# Altium schematic sheet Sheet7.SchDoc
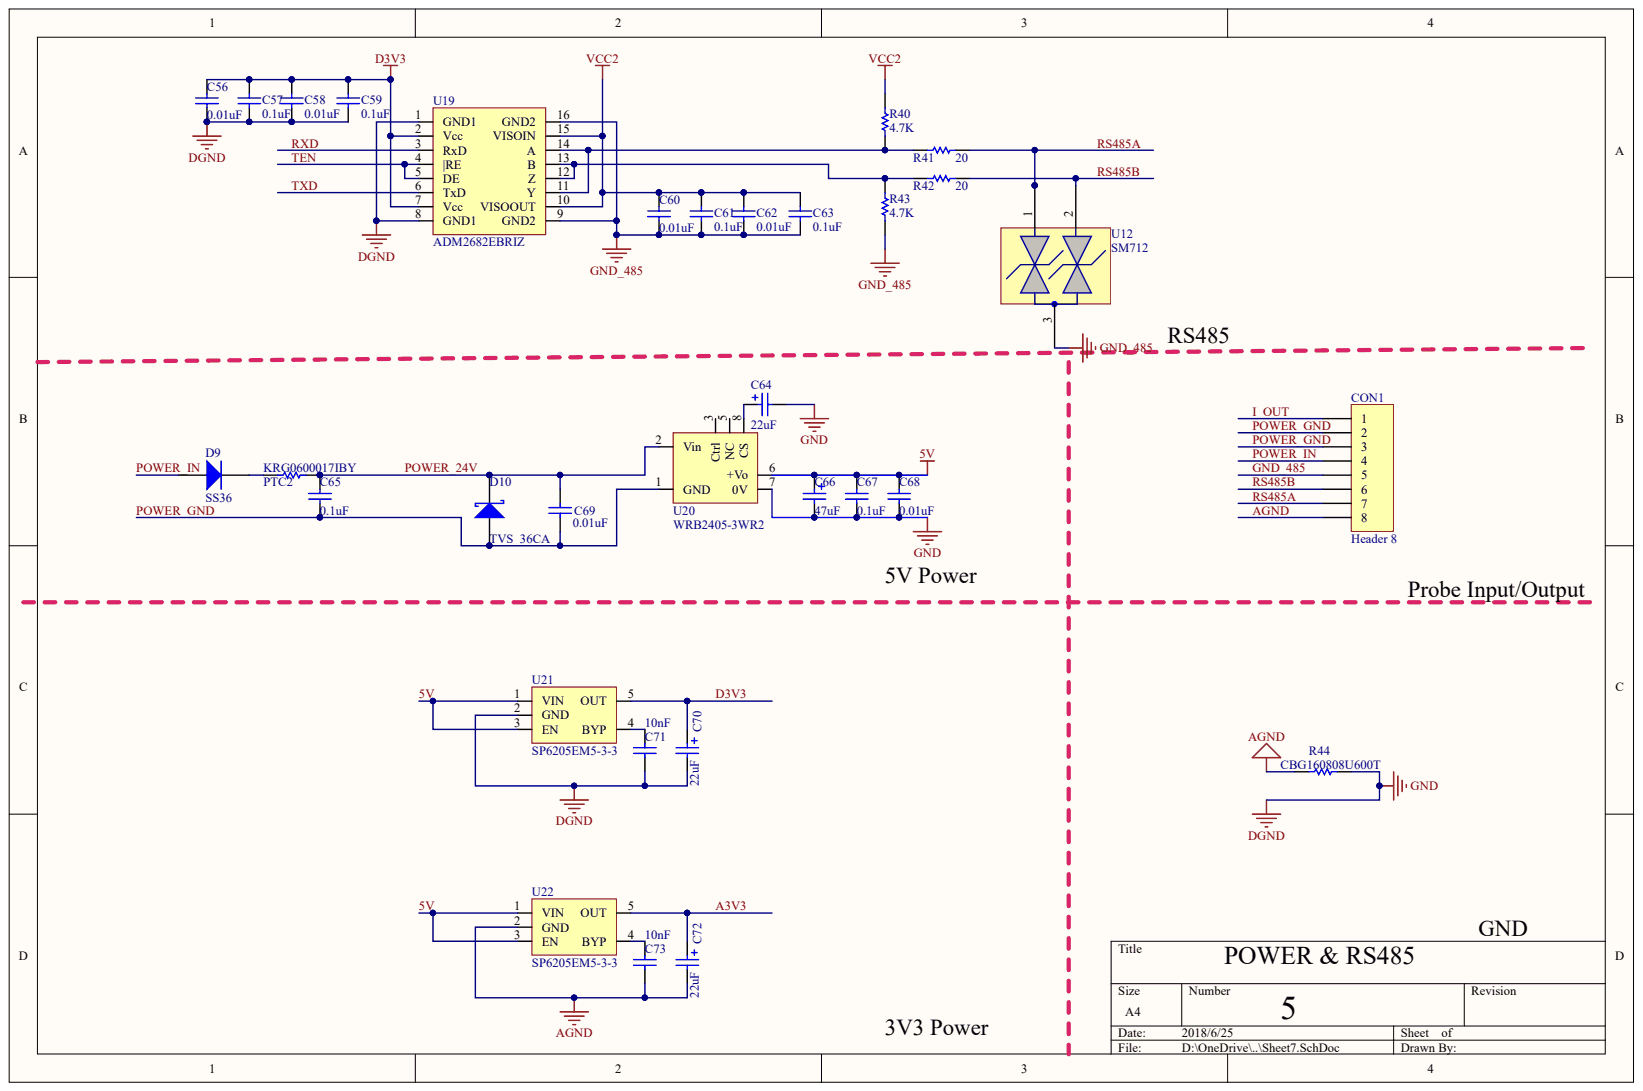
[diagram p0: Sheet7.SchDoc]

## Parts
C56  value 0.01uF  (hidden comment: Cap)
C57  value 0.1uF  (hidden comment: Cap)
C58  value 0.01uF  (hidden comment: Cap)
C59  value 0.1uF  (hidden comment: Cap)
C60  value 0.01uF  (hidden comment: Cap)
C61  value 0.1uF  (hidden comment: Cap)
C62  value 0.01uF  (hidden comment: Cap)
C63  value 0.1uF  (hidden comment: Cap)
C64  value 22uF  (hidden comment: Cap Pol2)
C65  value 0.1uF  (hidden comment: Cap)
C66  value 47uF  (hidden comment: Cap Pol2)
C67  value 0.1uF  (hidden comment: Cap)
C68  value 0.01uF  (hidden comment: Cap)
C69  value 0.01uF  (hidden comment: Cap)
C70  value 22uF  (hidden comment: Cap Pol2)
C71  value 10nF  (hidden comment: Cap)
C72  value 22uF  (hidden comment: Cap Pol2)
C73  value 10nF  (hidden comment: Cap)
CON1  Header 8
D9  SS36
D10  TVS_36CA
PTC2  value KRG0600017IBY  (hidden comment: Res1)
R40  value 4.7K  (hidden comment: Res1)
R41  value 20  (hidden comment: Res1)
R42  value 20  (hidden comment: Res1)
R43  value 4.7K  (hidden comment: Res1)
R44  value CBG160808U600T  (hidden comment: Magnetic beads)
U12  SM712
U19  ADM2682EBRIZ
U20  WRB2405-3WR2
U21  SP6205EM5-3-3
U22  SP6205EM5-3-3

## Nets
DGND: C56.1 C57.1 C58.1 C59.1 C64.2 C66.2 C67.1 C68.1 C70.2 C71.2 R44.2 U19.1(GND1) U19.8(GND1) U20.7(0V) U21.2(GND)
VCC2: C60.2 C61.2 C62.2 C63.2 R40.2 U19.10(VISOOUT) U19.15(VISOIN)
5V: C66.1 C67.2 C68.2 U20.6(+Vo) U21.1(VIN) U21.3(EN) U22.1(VIN) U22.3(EN)
A3V3: C72.1 U22.5(OUT)
AGND: C72.2 C73.2 CON1.8 R44.1 U22.2(GND)
D3V3: C56.2 C57.2 C58.2 C59.2 C70.1 U19.2(Vcc) U19.7(Vcc) U21.5(OUT)
GND_485: C60.1 C61.1 C62.1 C63.1 CON1.5 R43.1 U12.3 U19.9(GND2) U19.16(GND2)
I_OUT: CON1.1
POWER_24V: C65.2 C69.2 D10.2 PTC2.1 U20.2(Vin)
POWER_GND: C65.1 C69.1 CON1.2 CON1.3 D10.1 U20.1(GND)
POWER_IN: CON1.4 D9.1
RS485A: CON1.7 R41.1 U12.1
RS485B: CON1.6 R42.1 U12.2
RXD: U19.3(RxD)
TEN: U19.4(|RE) U19.5(DE)
TXD: U19.6(TxD)
NetC64_1: C64.1 U20.8(CS)
NetC71_1: C71.1 U21.4(BYP)
NetC73_1: C73.1 U22.4(BYP)
NetD9_2: D9.2 PTC2.2
NetR40_1: R40.1 R41.2 U19.11(Y) U19.14(A)
NetR42_2: R42.2 R43.2 U19.12(Z) U19.13(B)

Not connected: U20 3(Ctrl) 5(NC)

## Library data of the parts
C56-C73 CON1 D9 D10 PTC2 R40-R44 U12 U19-U22 = (no symbol name)
  C56-C63 C65 C67-C69 C71 C73: Published: 8-Jun-2000; LatestRevisionDate: 17-Jul-2002; LatestRevisionNote: Re-released for DXP Platform.; PackageReference: RAD-0.3
  C64 C66 C70 C72: Published: 8-Jun-2000; LatestRevisionDate: 17-Jul-2002; LatestRevisionNote: Re-released for DXP Platform.; PackageReference: POLAR0.8
  CON1: LatestRevisionDate: 17-Jul-2002; LatestRevisionNote: Re-released for DXP Platform.
  D9: Published: 8-Jun-2000; LatestRevisionDate: 17-Jul-2002; LatestRevisionNote: Re-released for DXP Platform.; DatasheetVersion: 1997; PackageReference: DO-35; Code_JEDEC: DO-204-AH; ComponentLink1Description: Manufacturer Link; ComponentLink1URL: http://www.fairchildsemi.com/; ComponentLink2Description: Datasheet; ComponentLink2URL: http://www.fairchildsemi.com/ds/1N%2F1N4148.pdf
  D10: Published: 8-Jun-2000; LatestRevisionDate: 17-Jul-2002; LatestRevisionNote: Re-released for DXP Platform.; PackageReference: SMB; Code_JEDEC: DO-214-AA
  PTC2 R40-R44: Published: 8-Jun-2000; LatestRevisionDate: 17-Jul-2002; LatestRevisionNote: Re-released for DXP Platform.; PackageReference: AXIAL-0.3
  C56-C73 CON1 D9 D10 PTC2 R40-R44: Publisher: Altium Limited
